# Altium schematic sheet RGBLed.SchDoc
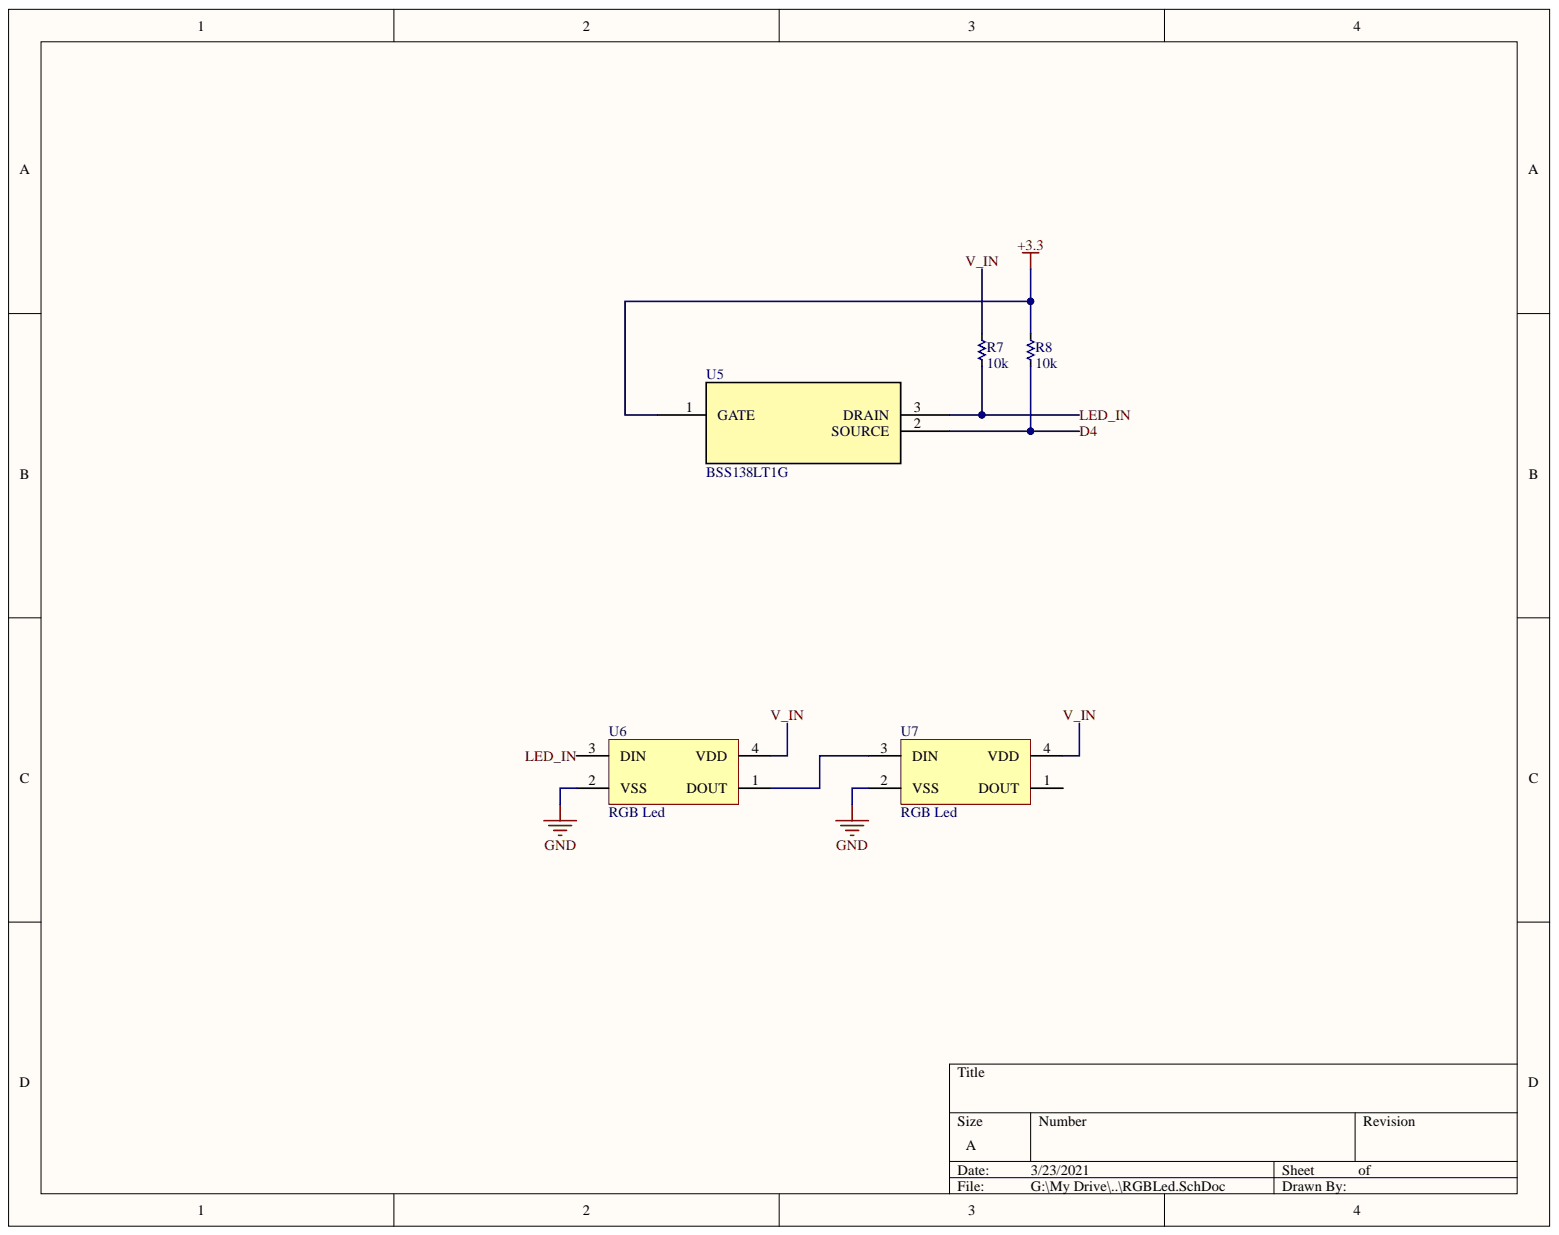
[diagram p0: RGBLed.SchDoc]

## Parts
R7  10k  [RES-SMD-0603]
R8  10k  [RES-SMD-0603]
U5  BSS138LT1G  [SOT23-3_ONS]
U6  RGB Led  [WS2812-2020]
U7  RGB Led  [WS2812-2020]

## Nets
+3.3: R8.1 U5.1
GND: U6.2(VSS) U7.2(VSS)
D4: R8.2 U5.2
LED_IN: R7.2 U5.3 U6.3(DIN)
V_IN: R7.1 U6.4(VDD) U7.4(VDD)
NetU6_1: U6.1(DOUT) U7.3(DIN)

Not connected: U7 1(DOUT)

## Library data of the parts
R7 R8 = Res-SMD: Resistor; Manufacturer: Generic Components; Class: Passive; Component Kind: Standard; Library Name: LIBRARY.IntLib; Published: 08/06/2000; Value: 10k; Publisher: Altium Hobart Technology Centre
U5 = BSS138LT1G: No Description Available; Built_By: EMA_Jessica; SourceLibrary: ON_Semiconductor_2019-12-30; Vendor: ON Semiconductor; Component Kind: Standard; Library Name: BSS138LT1G.IntLib; Manufacturer_Part_Number: BSS138LT1G; RefDes: RefDes; Type: DEV; Copyright: Copyright (C) 2018 Accelerated Designs. All rights reserved
U6 U7 = WS2812-2020: RGB 620~630nm Red, 515~530nm Green, 465~475nm Blue SMD, 5x5mm Light Emitting Diodes (LED) RoHS; Component Kind: Standard; Library Name: WS2812-2020.IntLib
